# Altium schematic sheet PowCarbinBoard_Sensor.SchDoc
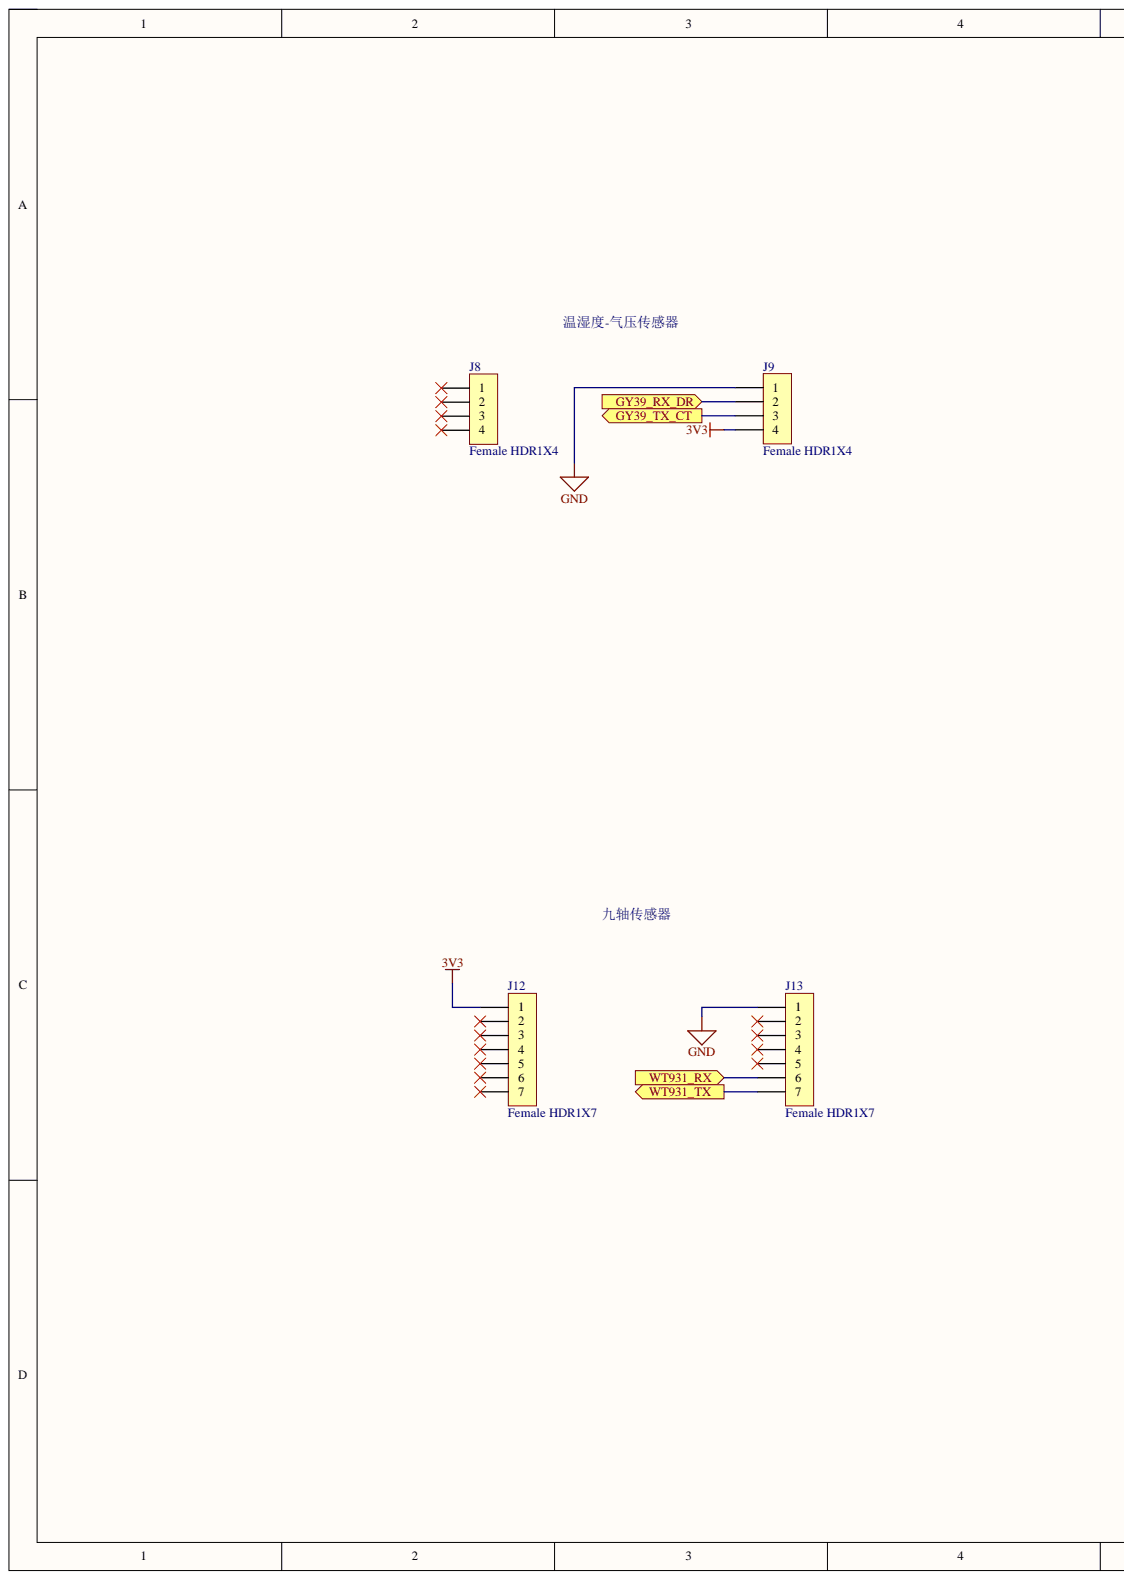
[diagram p0: PowCarbinBoard_Sensor.SchDoc, left]
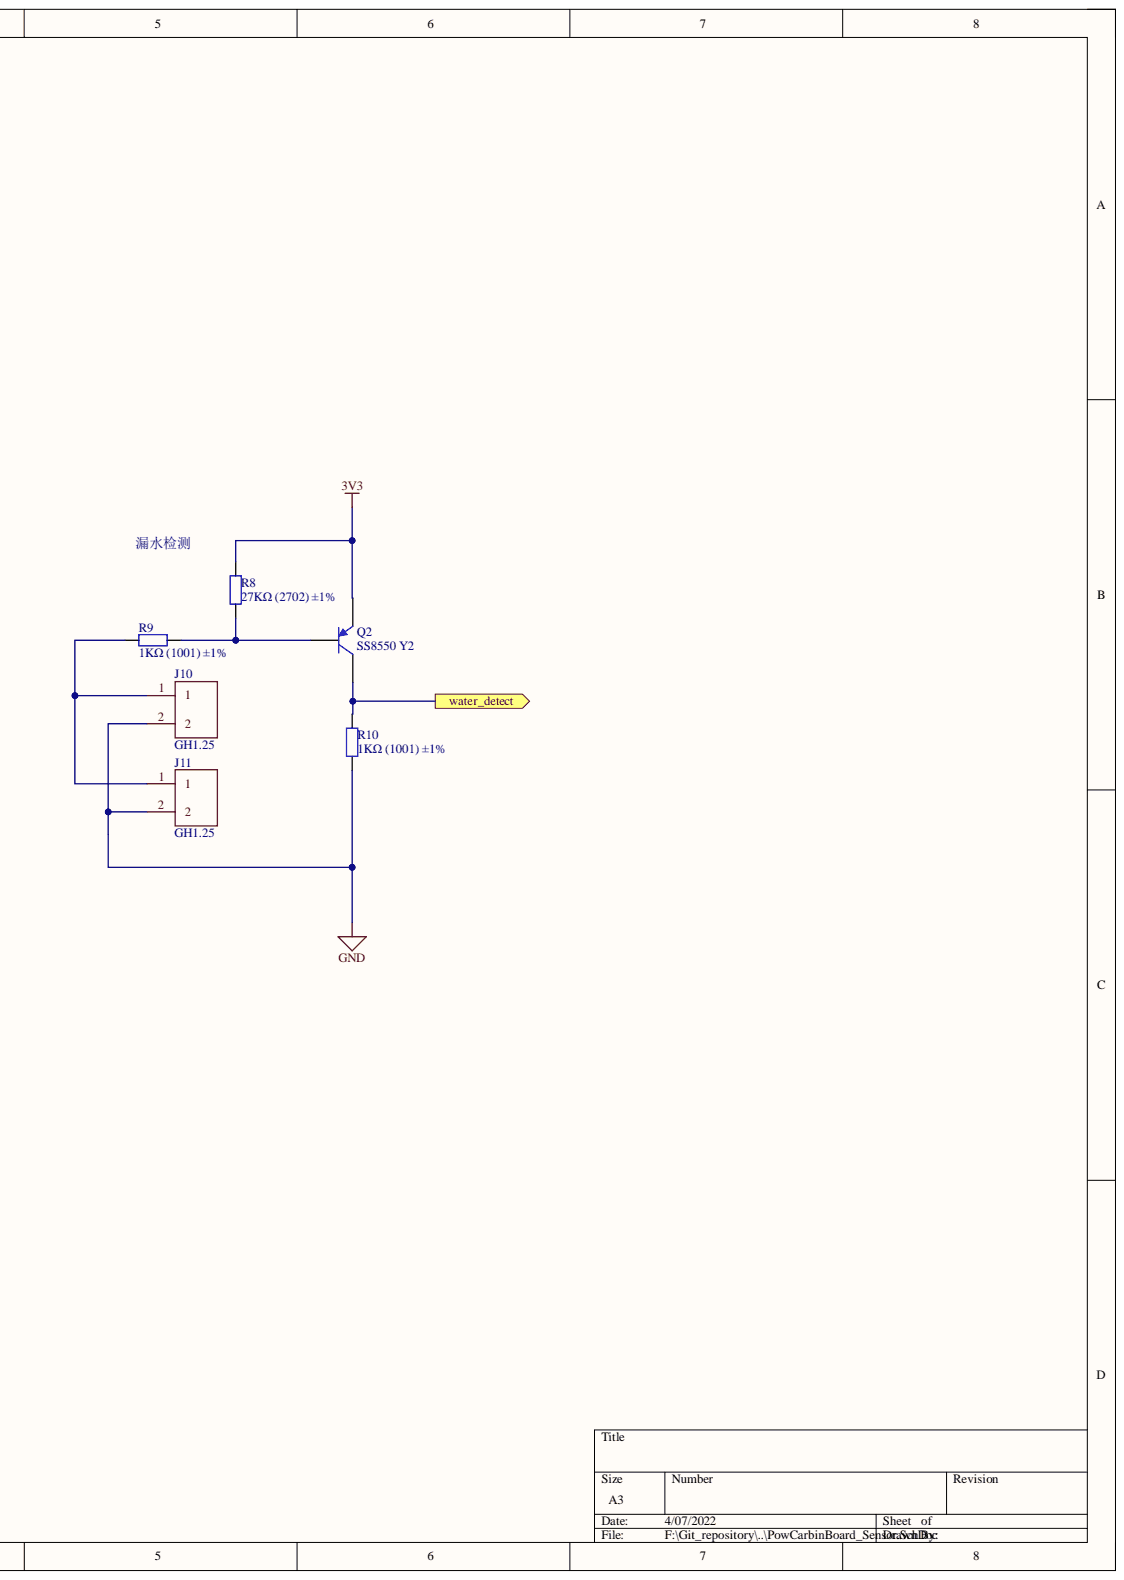
[diagram p1: PowCarbinBoard_Sensor.SchDoc, right]

## Parts
J8  Female HDR1X4  [Fe-HDR1X4/2.54-S]
J9  Female HDR1X4  [Fe-HDR1X4/2.54-S]
J10  GH1.25  [GH1.25 2pin]
J11  GH1.25  [GH1.25 2pin]
J12  Female HDR1X7  [Fe-HDR1X7/2.54-S]
J13  Female HDR1X7  [Fe-HDR1X7/2.54-S]
Q2  SS8550 Y2  [SOT-23(SOT-23-3)]
R8  27KΩ (2702) ±1%  [0603_R]
R9  1KΩ (1001) ±1%  [0603_R]
R10  1KΩ (1001) ±1%  [0603_R]

## Ports
GY39_RX_DR, GY39_TX_CT, water_detect, WT931_RX, WT931_TX

## Nets
3V3: J9.4 J12.1 Q2.2 R8.2
GND: J9.1 J10.2 J11.2 J13.1 R10.1
GY39_RX_DR: J9.2 ; port GY39_RX_DR
GY39_TX_CT: J9.3 ; port GY39_TX_CT
water_detect: Q2.3 R10.2 ; port water_detect
WT931_RX: J13.6 ; port WT931_RX
WT931_TX: J13.7 ; port WT931_TX
NetJ8_1: J8.1
NetJ8_2: J8.2
NetJ8_3: J8.3
NetJ8_4: J8.4
NetJ10_1: J10.1 J11.1 R9.1
NetJ12_2: J12.2
NetJ12_3: J12.3
NetJ12_4: J12.4
NetJ12_5: J12.5
NetJ12_6: J12.6
NetJ12_7: J12.7
NetJ13_2: J13.2
NetJ13_3: J13.3
NetJ13_4: J13.4
NetJ13_5: J13.5
NetQ2_1: Q2.1 R8.1 R9.2

## Library data of the parts
J10 J11 = GH1.25 2pin: Component Kind: Standard; Library Name: MySchLib.SchLib
Q2 = SOT-23 SS8550 Y2: 三极管; Component Kind: Standard; ComponentLink1Description: 供应商链接; ComponentLink1URL: http://www.szlcsc.com/product/details_9037.html; Library Name: Miscellaneous Devices LC.IntLib; Package: SOT-23; Supplier: LC; SuppliersPartNumber: C8542
R8 = 0603 27KΩ (2702) 1%: 贴片电阻; Component Kind: Standard; ComponentLink1Description: 供应商链接; ComponentLink1URL: http://www.szlcsc.com/product/details_23694.html; Library Name: Miscellaneous Devices LC.IntLib; Package: 0603; Supplier: LC; SuppliersPartNumber: C22967
R9 R10 = 0603 1KΩ (1001) 1%: 贴片电阻; Component Kind: Standard; ComponentLink1Description: 供应商链接; ComponentLink1URL: http://www.szlcsc.com/product/details_21904.html; Library Name: Miscellaneous Devices LC.IntLib; Package: 0603; Supplier: LC; SuppliersPartNumber: C21190
J8 J9 = Female HDR1X4: Component Kind: Standard; Library Name: 排母.IntLib
J12 J13 = Female HDR1X7: Component Kind: Standard; Library Name: 排母.IntLib
